# Altium schematic sheet RF_STM.SchDoc(RF_STM)
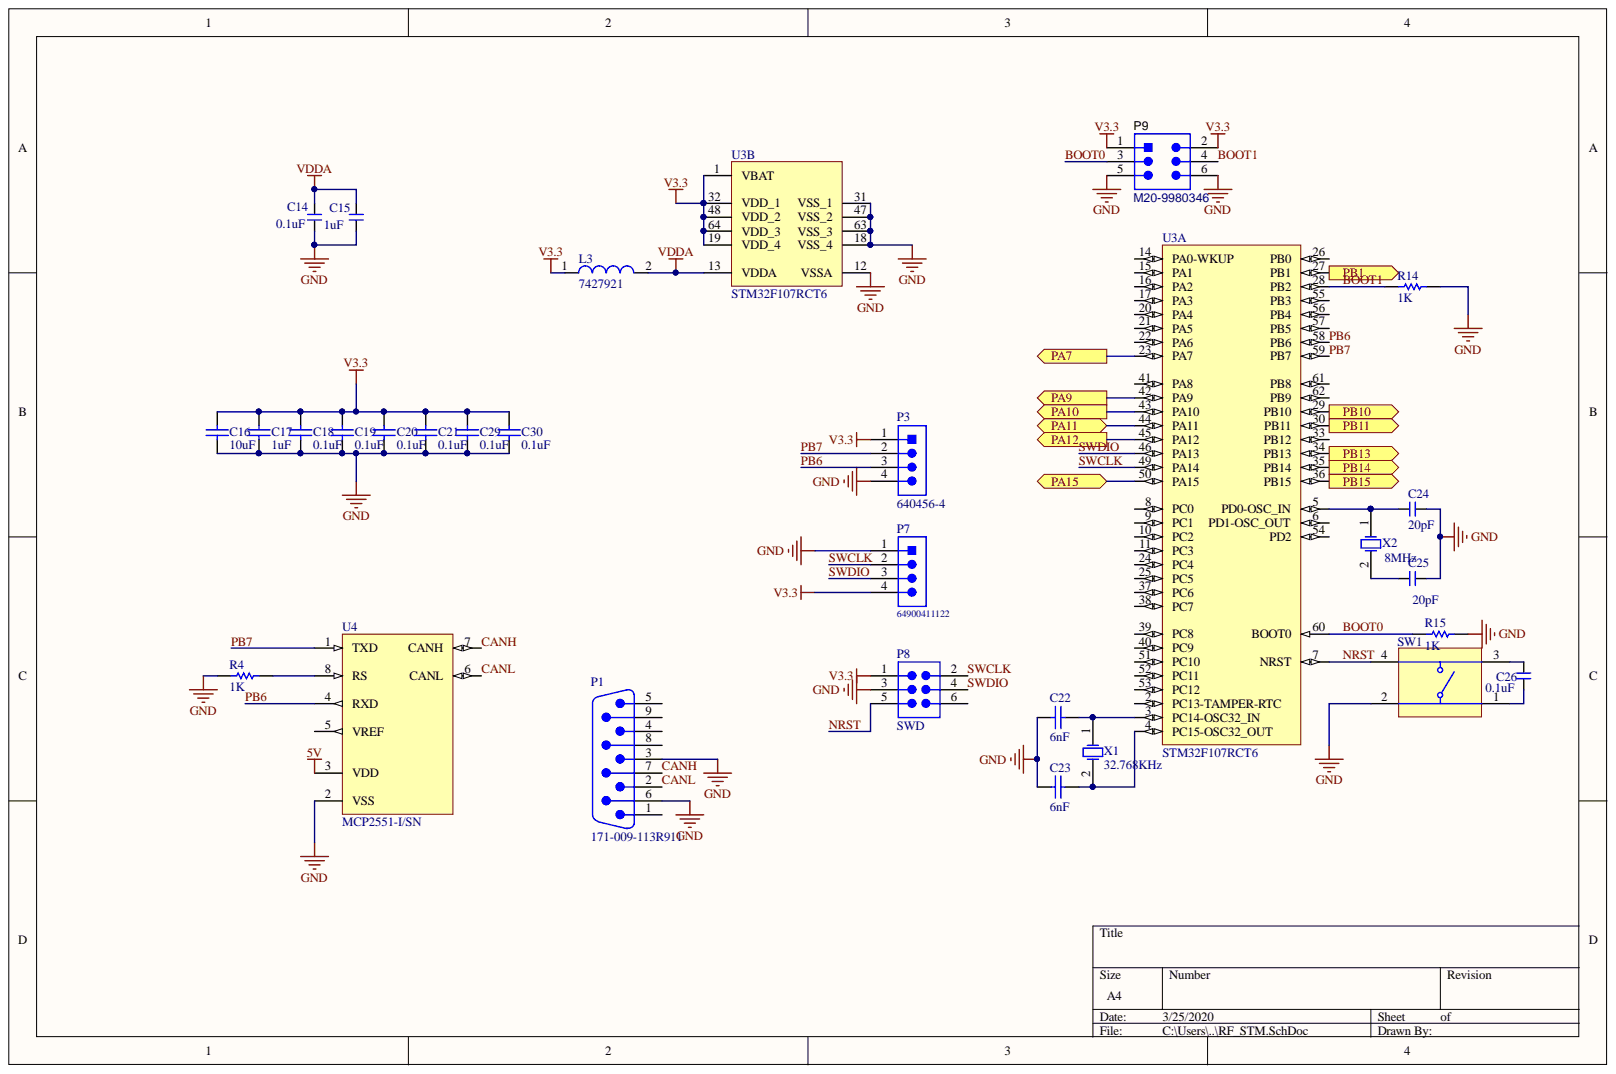
[diagram p0: RF_STM.SchDoc]

## Parts
C14  0.1uF  [C0805]
C15  1uF  [C0805]
C16  10uF  [CAPC3326X280X60LL40T25]
C17  1uF  [CAPC3225X270X30LL30]
C18  0.1uF  [CAPC2013X135X50LL10T20]
C19  0.1uF  [CAPC2013X135X50LL10T20]
C20  0.1uF  [CAPC2013X135X50LL10T20]
C21  0.1uF  [CAPC2013X135X50LL10T20]
C22  6nF  [CAPC2013X140X50LL20T25]
C23  6nF  [CAPC2013X140X50LL20T25]
C24  20pF  [C0805]
C25  20pF  [C0805]
C26  0.1uF  [C0805]
C29  0.1uF  [CAPC2013X135X50LL10T20]
C30  0.1uF  [CAPC2013X135X50LL10T20]
L3  7427921  [SMD-1206]
P1  171-009-113R911  [NORCO-171-009-113R911_V]
P3  640456-4  [TECO-640456-4]
P7  64900411122  [64900411122]
P8  SWD  [SMTC-TSW-103-08-X-D]
P9  M20-9980346  [HRWN-M20-9980346_V]
R4  1K  [RESC1608X55X25ML10T15]
R14  1K  [RESC2013X50X30NL20T20]
R15  1K  [RESC2013X50X30NL20T20]
SW1  PTS645SM43SMTR92LFS  [ITT-PTS645S-4_V]  (value not drawn)
U3  STM32F107RCT6  [STM-LQFP64_M]  (parts A,B)
U4  MCP2551-I/SN  [SOIC-SN8_N]
X1  32.768KHz  [ABRA-ABS07-2_V]
X2  8MHz  [ABRA-ABM3-2_V]

## Ports
PA7, PA9, PA10, PA11, PA12, PA15, PB1, PB10, PB11, PB13, PB14, PB15

## Nets
5V: U4.3(VDD)
GND: C14.2 C15.1 C16.1 C17.1 C18.1 C19.1 C20.1 C21.1 C22.1 C23.1 C24.1 C25.1 C29.1 C30.1 P1.3 P1.6 P3.4 P7.1 P8.3 P9.5 P9.6 R4.1 R14.1 R15.1 SW1.2 U3.12(VSSA) U3.18(VSS_4) U3.31(VSS_1) U3.47(VSS_2) U3.63(VSS_3) U4.2(VSS)
V3.3: C16.2 C17.2 C18.2 C19.2 C20.2 C21.2 C29.2 C30.2 L3.1 P3.1 P7.4 P8.1 P9.1 P9.2 U3.1(VBAT) U3.19(VDD_4) U3.32(VDD_1) U3.48(VDD_2) U3.64(VDD_3)
VDDA: C14.1 C15.2 L3.2 U3.13(VDDA)
BOOT0: P9.3 R15.2 U3.60(BOOT0)
BOOT1: P9.4 R14.2 U3.28(PB2)
CANH: P1.7 U4.7(CANH)
CANL: P1.2 U4.6(CANL)
NRST: P8.5 SW1.4 U3.7(NRST)
PA7: U3.23(PA7) ; port PA7
PA9: U3.42(PA9) ; port PA9
PA10: U3.43(PA10) ; port PA10
PA11: U3.44(PA11) ; port PA11
PA12: U3.45(PA12) ; port PA12
PA15: U3.50(PA15) ; port PA15
PB1: U3.27(PB1) ; port PB1
PB6: P3.3 U3.58(PB6) U4.4(RXD)
PB7: P3.2 U3.59(PB7) U4.1(TXD)
PB10: U3.29(PB10) ; port PB10
PB11: U3.30(PB11) ; port PB11
PB13: U3.34(PB13) ; port PB13
PB14: U3.35(PB14) ; port PB14
PB15: U3.36(PB15) ; port PB15
SWCLK: P7.2 P8.2 U3.49(PA14)
SWDIO: P7.3 P8.4 U3.46(PA13)
NetC22_2: C22.2 U3.3(PC14-OSC32_IN) X1.1
NetC23_2: C23.2 U3.4(PC15-OSC32_OUT) X1.2
NetC24_2: C24.2 U3.5(PD0-OSC_IN) X2.1
NetC25_2: C25.2 X2.2
NetC26_1: C26.1 SW1.3
NetC26_2: C26.2 SW1.1
NetR4_2: R4.2 U4.8(RS)

Not connected: P1 1 4 5 8 9; P8 6; U3 2(PC13-TAMPER-RTC) 6(PD1-OSC_OUT) 8(PC0) 9(PC1) 10(PC2) 11(PC3) 14(PA0-WKUP) 15(PA1) 16(PA2) 17(PA3) 20(PA4) 21(PA5) 22(PA6) 24(PC4) 25(PC5) 26(PB0) 33(PB12) 37(PC6) 38(PC7) 39(PC8) 40(PC9) 41(PA8) 51(PC10) 52(PC11) 53(PC12) 54(PD2) 55(PB3) 56(PB4) 57(PB5) 61(PB8) 62(PB9); U4 5(VREF)

## Library data of the parts
C14 C26 = CL21B104KBCWPNC: CAP CER 0.1UF 50V X7R 0805; Manufacturer: Samsung; Tolerance: 10%; Capacitance: 100nF; Width: 0.049inch; Voltage Rating: 50V; Thickness: 0.053inch; Supplier Part Number 1: 1276-6557-1-ND; Supplier 1: Digi-Key; Stock 1: 6545; RoHS: Yes; Ratings: AEC-Q200; Pricing 1: 1 = 0.10000, 10 = 0.06700, 100 = 0.02990, 500 = 0.02138, 1000 = 0.01680 (USD); Packaging: Tape and Reel; Mount: Surface Mount; Min Operating Temperature: -55°C; Max Operating Temperature: 125°C; Manufacturer Part Number: CL21B104KBCWPNC; Length: 0.079inch; Features: Soft Termination; Component Kind: Standard; Category: Capacitors; Case Code (Metric): 2012; Case Code (Imperial): 0805; Case/Package: 0805; Library Name: MCB.SchLib
C15 = CL21B105KAFNNNE: Cap Ceramic 1uF 25V X7R 10% SMD 0805 125C T/R; Manufacturer: Samsung; Width: 0.049inch; Voltage Rating (DC): 25V; Voltage Rating: 25V; Voltage: 25V; Tolerance: 10%; Thickness: 0.053inch; Supplier Part Number 1: 1276-1066-1-ND; Supplier 1: Digi-Key; Stock 1: 1606570; RoHS: Yes; Radiation Hardening: No; Pricing 1: 1 = 0.10000, 10 = 0.07600, 100 = 0.03380, 500 = 0.02416, 1000 = 0.01898 (USD); Packaging: Tape and Reel; Package Quantity: 1; Mount: Surface Mount; Min Operating Temperature: -55°C; Max Operating Temperature: 125°C; Manufacturer Part Number: CL21B105KAFNNNE; Length: 2mm; Lead Free: Lead Free; Height: 1.25mm; Dielectric: X7R; Depth: 1.25mm; Contact Plating: Tin; ComponentLink2URL: https://datasheet.ciiva.com/15195/getdatasheetpartid-355205-15195880.pdf?src-supplier=Verical; ComponentLink2Description: https://datasheet.ciiva.com/15195/getdatasheetpartid-355205-15195880.pdf?src-supplier=Verical; ComponentLink1URL: https://datasheet.ciiva.com/25800/cl21b105kafnnne-25800163.pdf?src-supplier=Digi-Key; ComponentLink1Description: https://datasheet.ciiva.com/25800/cl21b105kafnnne-25800163.pdf?src-supplier=Digi-Key; Component Kind: Standard; Category: Capacitors; Case Code (Metric): 2012; Case Code (Imperial): 0805; Case/Package: 0805; Capacitance: 1uF; Library Name: MCB.SchLib
C16 = CMP-2000-06021-1: Chip Capacitor, 10 uF, +/- 10%, 25 V, -55 to 125 degC, 1210 (3225 Metric), RoHS, Tape and Reel; Manufacturer: Kemet; Voltage - Rated: 25V; Temperature Coefficient: X7R; Product Training Modules: CapacitorBasics-TypicalUsesforCapacitors; Other Names: 399-8241-6; Online Catalog: FT-CAP; Capacitance: 10µF; Tolerance: ±10%; Thickness (Max): 0.110"(2.80mm); Standard Package: 1; Size / Dimension: 0.126"Lx0.098"W(3.20mmx2.50mm); Series: FT-CAP; Ratings: -; Packaging: Digi-Reel®; Package Reference: 1210; Package Description: 2-Pin Surface Mount Device, Body 3.3 x 2.6 mm; Package / Case: 1210(3225Metric); Operating Temperature: -55°C~125°C; Mounting Type: SurfaceMount,MLCC; Mounting Technology: SurfaceMount; Manufacturer URL: http://www.kemet.com/; Library Name: Altium Content Vault; Lead Style: -; Lead Spacing: -; Height - Seated (Max): -; Features: SoftTermination; Family: CeramicCapacitors; Datasheet Version: 02/2016; Datasheet URL: http://www.kemet.com/docfinder?Partnumber=C1210X106K3RACTU; Component Kind: Standard; Category: Capacitors; Applications: BoardflexSensitive
C17 = CMP-2000-06366-1: Chip Capacitor, 1 uF, +/- 10%, 100 V, -55 to 125 degC, 1210 (3225 Metric), RoHS, Tape and Reel; Manufacturer: Murata; Voltage - Rated: 100V; Temperature Coefficient: X7R; Product Training Modules: High-CapMultilayerCeramicCapacitors; Other Names: 490-1857-6; Online Catalog: GRMSeries; Featured Product: GA3SafetyRecognizedCapsandHi-CapMLCC; Catalog Drawings: GRMSeries_1210_2.5; Capacitance: 1µF; Tolerance: ±10%; Thickness (Max): 0.106"(2.70mm); Standard Package: 1; Size / Dimension: 0.126"Lx0.098"W(3.20mmx2.50mm); Series: GRM; Ratings: -; Packaging: Digi-Reel®; Package Reference: 1210; Package Description: 2-Pin Surface Mount Device, Body 3.2 x 2.5 mm; Package / Case: 1210(3225Metric); Operating Temperature: -55°C~125°C; Mounting Type: SurfaceMount,MLCC; Mounting Technology: SurfaceMount; Manufacturer URL: http://www.murata.com/; Library Name: Altium Content Vault; Lead Style: -; Lead Spacing: -; Height - Seated (Max): -; Features: -; Family: CeramicCapacitors; Datasheet Version: 02/2016; Datasheet URL: http://search.murata.co.jp/Ceramy/image/img/A01X/G101/ENG/GRM32ER72A105KA01-01.pdf; Component Kind: Standard; Category: Capacitors; Applications: GeneralPurpose
C18-C21 C29 C30 = CMP-2007-03453-1: Part Number: GRM21BR61C106KE15L; Manufacturer: Murata; Library Name: Altium Content Vault; Component Kind: Standard
C22 C23 = CMP-2000-05810-1: Chip Capacitor, 2.2 uF, +/- 10%, 10 V, -55 to 85 degC, 0805 (2012 Metric), RoHS, Tape and Reel; Manufacturer: AVX; Rated Voltage: 10V; Dielectric: X5R; Capacitance: 2.2µF; Tolerance (%): ±10%; Package Reference: 0805; Package Description: 2-Pin Surface Mount Device, Body 2.01 x 1.25 mm; Mounting Technology: SurfaceMount; Manufacturer URL: http://www.avx.com/; Library Name: Altium Content Vault; Datasheet Version: 05/2016; Datasheet URL: http://datasheets.avx.com/cx5r.pdf; Component Kind: Standard; Case Size/Dimension: 0805
C24 C25 = C0805C200J5GACTU: CAP CER 20PF 50V C0G/NP0 0805; Manufacturer Part Number: CL21B103KBANNNC; Manufacturer: Samsung; Tolerance: 10%; Material: Ceramic; Dielectric: X7R; Capacitance: 10nF; Width: 0.049inch; Voltage Rating (DC): 50V; Voltage Rating: 50V; Voltage: 50V; Thickness: 0.03inch; Supplier Part Number 1: 399-8033-1-ND; Supplier 1: Digi-Key; RoHS: Yes; Radiation Hardening: No; Packaging: Tape and Reel; Mount: Surface Mount; Min Operating Temperature: -55°C; Max Operating Temperature: 125°C; Length: 2mm; Lead Free: Lead Free; Height: 0.65mm; Depth: 1.25mm; Contact Plating: Tin; ComponentLink1URL: https://www.digikey.com/product-detail/en/kemet/C0805C200J5GACTU/399-8033-1-ND/3471756; ComponentLink1Description: https://www.digikey.com/product-detail/en/kemet/C0805C200J5GACTU/399-8033-1-ND/3471756; Component Kind: Standard; Category: Capacitors; Case Code (Metric): 2012; Case Code (Imperial): 0805; Case/Package: 0805; Library Name: MCB.SchLib
L3 = CMP-0220-00079-1: SMD EMI Suppression Ferrite Bead WE-CBF, Z = 32 Ohm; Impedance: 32 Ohm; PackageVersion: 2007; PackageReference: SMD-1206; PackageDescription: Chip Inductor, 2-Leads, Body 3.2 x 1.6 mm; Library Name: Altium Content Vault; DatasheetVersion: Dec-2005; ComponentLink2URL: http://www.we-online.de/katalog/media/pdf/7427921.pdf; ComponentLink2Description: Datasheet; ComponentLink1URL: http://www.we-online.com; ComponentLink1Description: Manufacturer Link; Component Kind: Standard
P1 = CMP-2000-05495-1: Male Header, Pitch 2.77 mm, 2 Row, 9 Position, Height 12.52 mm, Tail Length 4.8 mm, -55 to 100 degC, RoHS, Tray; Manufacturer: Norcomp; Product Training Modules: D-SubConnectors; Other Related Documents: 171-009-113R911MaterialDeclaration; Other Names: 171009113R9119209ME; Online Catalog: 171Series; Number of Positions: 9; Mating Products: 4209FE-ND-CONND-SUBRCPT9POSVERTSOLDER709FE-ND-CONNDB9CRIMPFEMALENICKEL709FER-ND-CONNDB9CRIMPFEMALETIN186-009-292L001-ND-CONNDSUBRCPT9POSSTRIDC186-009-292L551-ND-CONNDSUBRCPT9POSSTRIDC209F-ND-CONNDSUBRCPT9POSSTRSLDRCUP709F-ND-CONNDB9CRIMPFEMALETIN1709F-ND-CONNDB9CRIMPFEMYLWCHROME4209F-ND-CONND-SUBRCPT9POSVERTSOLDER1709FA-ND-CONNDB9CRIMPFEMYLWCHROME209FEA-ND-CONNDSUBRCPT9POSSTRSLDRCUP; Flange Feature: MatingSide,FemaleScrewlock(4-40); Current Rating: 5A; Connector Type: Plug,MalePins; Connector Style: D-Sub; Catalog Drawings: Footprint9,15,25-,37Position171,172SeriesDipSolder; Associated Product: 160-09MA-ND-DUSTCOVERFORDB9MALE; 3D Model: 171-009-113Rzzz.pdf171-009-113Rzzz.stp171-009-113Rzzz.igs; Voltage Rating: -; Termination: Solder; Standard Package: 80; Shell Size, Connector Layout: 1(DE,E); Shell Material, Finish: Steel,NickelPlated; Series: 171; Packaging: Tray; Package Reference: 171-009-113R911; Package Description: Male Header, Pitch 2.77 mm, 2 Row, 9 Position, Height 12.52 mm, Tail Length 4.8 mm; Operating Temperature: -50°C~100°C; Number of Rows: 2; Mounting Type: ThroughHole; Mounting Technology: ThroughHole; Manufacturer URL: http://www.norcomp.net/; Library Name: Altium Content Vault; Ingress Protection: -; Housing Material: Polyamide(PA9T),Nylon9T; Features: BoardLock,GroundingIndents; Family: D-SubConnectors; Datasheet Version: Rev. 11, 09/2015; Datasheet URL: http://www.norcomp.net/rohspdfs/Connectors/17Y/171/171-YYY-113RYY1.pdf; Contact Type: Signal; Contact Finish Thickness: Flash; Contact Finish: Gold; Component Kind: Standard; Color: Black; Category: Connectors,Interconnects
P3 = CMP-2000-05419-1: Male Header, Pitch 2.54 mm, 1 x 4 Position, Height 10.03 mm, Tail Length 3.56 mm, RoHS, Bulk; Manufacturer: TE Connectivity; Voltage, Rating: 250    V; Temperature, Operating: -55to+105    degC; Standards: RoHSCompliant,ELVCompliant,UL94V-0; Special Features: Pinstrip; Primary Type: InterconnectSystem; Pin Spacing: 0.1    "; Number of Positions: 4; Mounting Hole Size: 0.04    "; Mount Angle: Vertical; Material, Housing: Polyester; Material, Contact: CopperAlloy; Current, Rating: 5    A; Contact Termination Area Plating: Tin; Contact Centerline Spacing: 0.100    in.; Connector Type: MTA-100; Color, Housing: White; Brand/Series: MTA-100Series; Angle: Straight; Agency Approvals: CSA,UL; Type: PCBPlug; Termination: Solder; Size, Post: 0.025    in.; Number of Rows: 1; Number of Contacts: 4; Mounting Type: PCMount; Manufacturer URL: http://www.te.com/; Library Name: Altium Content Vault; Length, Terminal Post: 0.14    in.; Length, Pin: 0.14    "; Length, Overall: 0.4    "; Flammability Rating: UL94V-0; Datasheet Version: Dec-14; Datasheet URL: https://datasheet.ciiva.com/16286/eng-ds-82056-mta-0608-16286228.pdf; Contact Shape: Square; Contact Plating: Tin; Contact Mating Area Plating: Tin; Component Kind: Standard; Color: White
P7 = CMP-1502-00554-1: THT Male Header WR-MPC4, Vertical, Single Row, pitch 4.2 mm, 4 pins; Manufacturer: Wurth Elektronik; Working Voltage: 600VAC; Pitch: 4.2mm; Pin Number: 4; Contact Resistance: 10mOhm; Part Number: 64900411122; Packaging: Tray; Package Reference: 64900411122; Orientation: Vertical; Operating Temperature: -40to105degC; Mounting Technology: THT; Manufacturer URL: http://www.we-online.de; Library Name: Altium Content Vault; Gender: Male; Datasheet URL: https://katalog.we-online.de/em/datasheet/6490xx11122.pdf; Component Kind: Standard; Publisher: WurthElektronik
P8 = CMP-1024-00338-1: 0.025" SQ Post Header, Through-hole, Vertical, -55 to 125 degC, 2.54 mm Pitch, 6-Pin, Male, RoHS; PartNumber: TSW-103-08-G-D; Manufacturer: Samtec; Pitch: 2.54 mm; Temperature Range: -55 to 125 degC; RoHS: True; PackageVersion: DM, 8/1988; PackageReference: TSW-103-08-X-D; PackageDescription: .025[0.64] SQ. Post Terminal Strip Assembly; Orientation: Vertical; Mounting Technology: Through-hole; Library Name: Altium Content Vault; DatasheetVersion: F-214; ComponentLink3URL: http://www.samtec.com/documents/webfiles/cpdf/TSW-XXX-XX-XXX-X-XX-XXX-MKT.pdf; ComponentLink3Description: Package Specification; ComponentLink2URL: http://www.samtec.com/documents/webfiles/pdf/TSW_TH.PDF; ComponentLink2Description: Datasheet; ComponentLink1URL: http://www.samtec.com; ComponentLink1Description: Manufacturer URL; Component Kind: Standard
P9 = CMP-2000-06636-1: Male Header, Pitch 2.54 mm, 2 x 3 Position, Height 8.64 mm, Tail Length 3 mm, -40 to 105 degC, RoHS, Bulk; Manufacturer: Harwin-Inc.; Row Spacing: 0.100"(2.54mm); Number of Positions Loaded: All; Number of Positions: 6; Connector Type: Header,Unshrouded,Breakaway; Termination: Solder; Series: M20; Pitch: 0.100"(2.54mm); Packaging: Bulk; Package Reference: M20-9980346; Package Description: Male Header, Pitch 2.54 mm, 2 x 3 Position, Height 8.64 mm, Tail Length 3 mm; Number of Rows: 2; Mounting Type: ThroughHole; Mounting Technology: ThroughHole; Manufacturer URL: https://www.harwin.com/; Library Name: Altium Content Vault; Features: -; Fastening Type: -; Family: RectangularConnectors-Headers,MalePins; Datasheet Version: 12/2006; Datasheet URL: https://datasheet.ciiva.com/26899/getdatasheetpartid-483857-26899433.pdf; Contact Type: MalePin; Contact Mating Length: 0.240"(6.10mm); Contact Finish Thickness: -; Contact Finish: Tin; Component Kind: Standard; Color: Black; Category: Connectors,Interconnects
R4 = CMP-2100-03664-1: Chip Resistor, 10 KOhm, +/- 1%, 01 W, -55 to 155 degC, 0603 (1608 Metric), RoHS, Tape and Reel; Manufacturer: Yageo; Voltage Rating (DC): 50V; Voltage Rating: 75V; Temperature Coefficient: 100ppm/degreesC; Resistance: 10KOhm; Power Rating: 100mW; Width: 0.8mm; Weight: 7.1E-05oz; Tolerance: 1%; Termination: SMD/SMT; RoHSCompliant: true; REACH SVHC: NoSVHC; Packaging: TapeandReel; Package Reference: 0603; Package Description: 2-Pin Surface Mount Device, Body 1.6 x 0.8 mm; Number of Pins: 2; Min Operating Temperature: -55degC; Military Standard: Not; Max Operating Temperature: 155degC; Manufacturer URL: http://www.yageo.com/; Library Name: Altium Content Vault; Length: 1.6mm; Lead Pitch: 0.3mm; Lead Free: LeadFree; Height: 0.45mm; Depth: 0.8mm; Datasheet Version: Rev. 5, 10/2016; Datasheet URL: http://www.yageo.com/NewPortal/yageodocoutput?fileName=/pdf/R-Chip/PYu-RC_Group_51_RoHS_L_5.pdf; Composition: ThickFilm; Component Kind: Standard; Case Code (Metric): 1608; Case Code (Imperial): 0603; Case/Package: 0603
R14 R15 = CMP-2001-03595-1: Part Number: CRCW08051K00FKEA; Manufacturer: Vishay Dale; Library Name: Altium Content Vault; Component Kind: Standard
SW1 = CMP-2000-05160-1: 6 mm Tact Switche, SPST, NO, -20 to 60 degC, 4-Pin SMT, RoHS, Tape and Reel; Manufacturer: ITT Cannon; Manufacturer URL: http://www.ittcannon.com/; Library Name: Altium Content Vault; Datasheet Version: Feb-14; Datasheet URL: https://datasheet.ciiva.com/29903/getdatasheetpartid-786341-29903846.pdf; Component Kind: Standard
U3 = CMP-0237-00079-3: ARM Cortex-M3 32-bit MCU, 256 KB Flash, 64 KB Internal RAM, 51 I/Os, 64-pin LQFP, -40 to 85 degC, Tray; Supply Voltage(Vcc) min (V): 2; Operating Frequency(F) (Processor speed) (MHz): 72; Internal RAM Size (kB): 64; I/Os (High Current): 51; FLASH Size (Prog) (kB): 256; D/A Converter: 2x12-bit (2 Channel); A/D Converter: 2x12-bit (16 Channel); PartNumber: STM32F107RCT6; Packing: Tray; PackageVersion: Rev. 4, 06/2013; PackageReference: LQFP64; PackageDescription: 64-Pin Low Profile Quad Flat Package, 10 x 10 mm Body, 0.5 mm Pitch; Mounting Technology: Surface Mount; Library Name: Altium Content Vault; DatasheetVersion: Rev. 6; Core: ARM Cortex-M3; ComponentLink3URL: http://www.st.com/st-web-ui/static/active/en/resource/technical/document/datasheet/DM00037051.pdf; ComponentLink3Description: Package Specification; ComponentLink2URL: http://www.st.com/st-web-ui/static/active/en/resource/technical/document/datasheet/CD00220364.pdf; ComponentLink2Description: Datasheet; ComponentLink1URL: http://www.st.com/web/en/home.html; ComponentLink1Description: Manufacturer URL; Component Kind: Standard
U4 = CMP-0186-00138-2: High-Speed CAN Transceiver, 8-Pin SOIC, Industrial Temperature; RevisionNote: URLs added. DatasheetVersion updated.; PackageVersion: revBB, Aug-2009; PackageReference: SOIC-SN8; PackageDescription: 8-Lead Plastic Small Outline (SN) - Narrow, 3.90mm Body [SOIC]; Library Name: Altium Content Vault; DatasheetVersion: revF, Jul-2010; ComponentLink3URL: http://www.microchip.com/stellent/groups/techpub_sg/documents/packagingspec/en012702.pdf; ComponentLink3Description: Package Specification; ComponentLink2URL: http://ww1.microchip.com/downloads/en/DeviceDoc/21667F.pdf; ComponentLink2Description: Datasheet; ComponentLink1URL: http://www.microchip.com/; ComponentLink1Description: Manufacturer URL; Component Kind: Standard; Code_JEDEC: MS-012; Code_IPC: SOIC127P600-8
X1 = CMP-0447-00001-2: Crystal, 32.768 kHz, 12.5 pF, -40 to 85 degC, SMD Low Profile 3.2 x 1.5 x 0.9 mm, Tape and Reel; PartNumber: ABS07-32.768KHz-T; Manufacturer: Abracon; Shunt Capacitance (C0): 0.9 to 1.2 pF; Q Value (Type): 30000; Operation Mode: Flexural Mode (Tuning Fork); Load Capacitance (CL): 12.5 pF; Insulation Resistance (Min): 500 Mohm; Frequency Tolerance @+25degC: +/- 20 ppm; Equivalent Series Resistance (R1): 70 Kohm; Drive Level (Type): 0.1 uW; Packing: Tape and Reel; PackageReference: ABS07-2; PackageDescription: 2-Pin Hand Drawn, Body 3.2x1.5mm, Pitch 2.5mm; Operating Temperature: -40 to 85 degC; Mounting Technology: Surface Mount; Library Name: Altium Content Vault; Frequency: 32.768 kHz; DatasheetVersion: 04.03.13; ComponentLink3URL: http://www.abracon.com/Resonators/ABS07.pdf; ComponentLink3Description: Package Specification; ComponentLink2URL: http://www.abracon.com/Resonators/ABS07.pdf; ComponentLink2Description: Datasheet; ComponentLink1URL: http://www.abracon.com/; ComponentLink1Description: Manufacturer URL; Component Kind: Standard
X2 = CMP-2000-05033-1: Miniature Ceramic Crystal, 8 MHz, -40 to 85 degC, 2-Pin SMD, RoHS, Tape and Reel; Manufacturer: ABRACON; Tolerance: 20PPM; Load Capacitance: 18pF; Frequency Stability: 30PPM; ESR: 140Ohms; Case Width: 3.2mm; Case Length: 5mm; Case Height: 1.3mm; Type: MiniatureCeramicCrystal; Termination Style: SMD/SMT; Series: ABM3; RoHS: Details; Product Category: Crystals; Packaging: Reel; Package URL: http://www.abracon.com/Support/PackageDrawing/Resonators/ABM3.PDF; Package Reference: ABM3-2; Package Description: 2-Pin Surface Mount Device, Body 5 x 3.2 mm; Package / Case: 5mmx3.2mm; Operating Temperature Range: -40Cto+85C; Mounting Style: SMD/SMT; Manufacturer URL: http://www.abracon.com/; Library Name: Altium Content Vault; Frequency: 8MHz; Factory Pack Quantity: 1000; Dimensions: 3.2mmWx5mmLx1.3mmH; Datasheet Version: Sep-13; Datasheet URL: http://www.farnell.com/datasheets/1754353.pdf; Component Kind: Standard; Brand: ABRACON
